# Altium schematic sheet FPGA-CFG.SchDoc(FPGA-CFG)
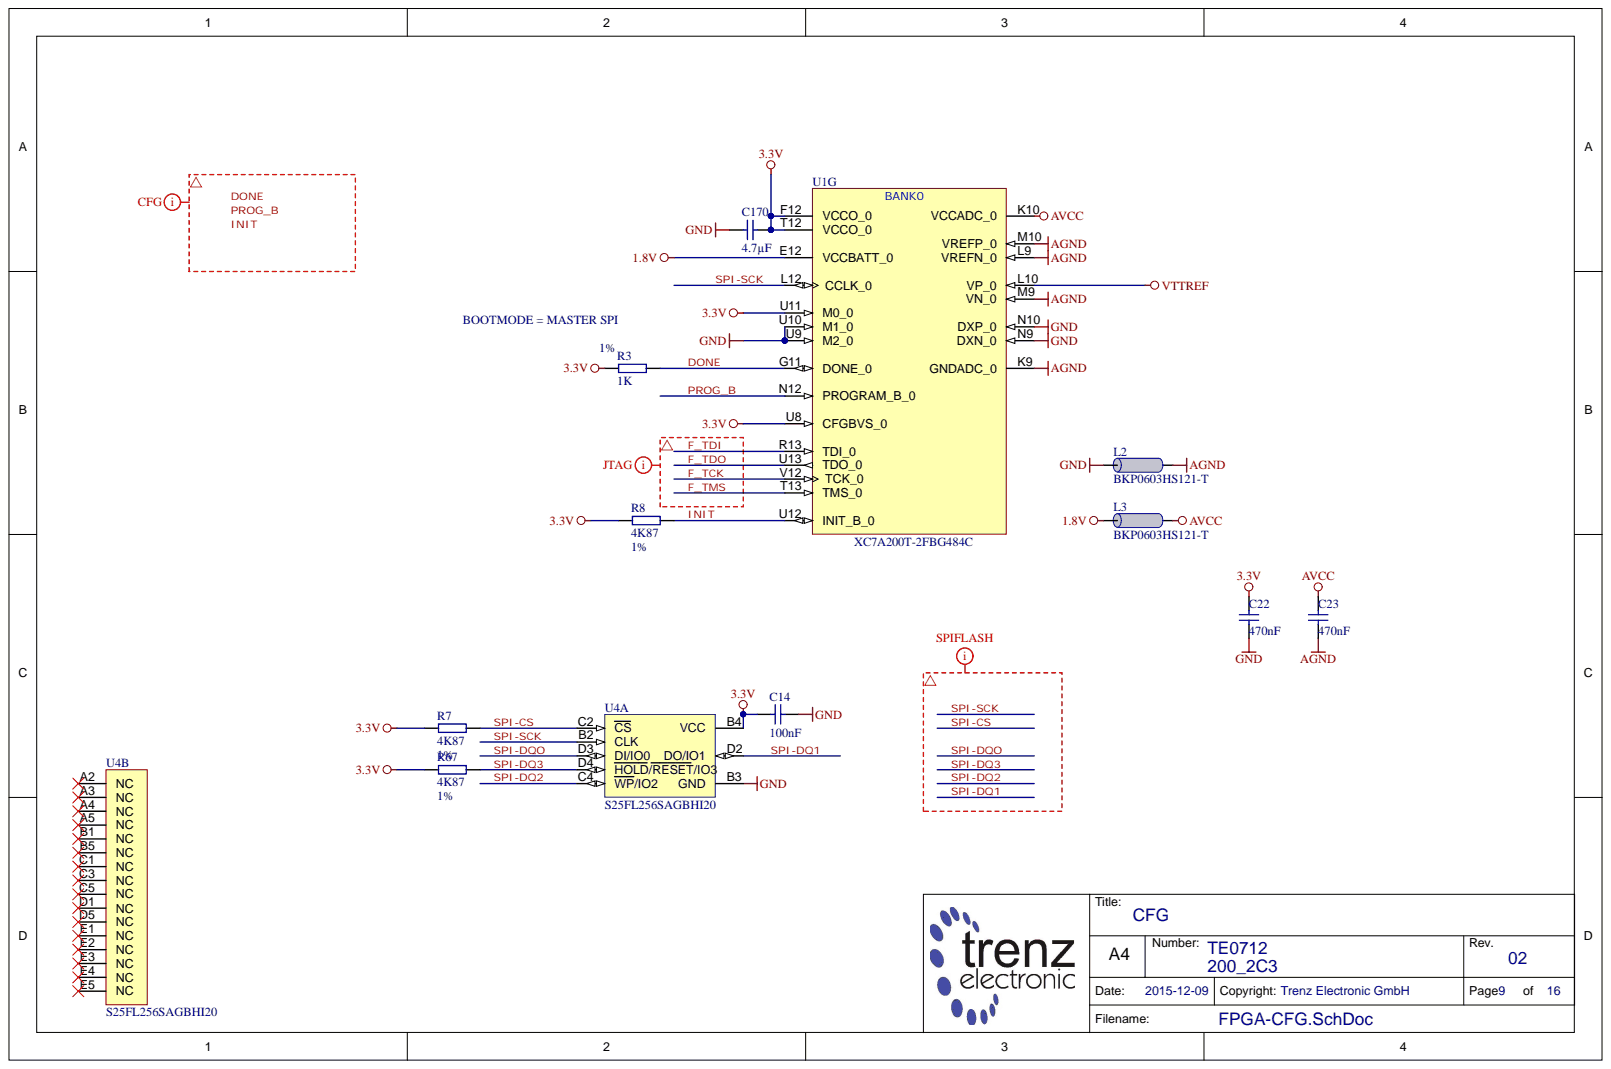
[diagram p0: FPGA-CFG.SchDoc]

## Parts
C14  100nF  [CAPC0603X33N]
C22  470nF  [CAPC0603X33N]
C23  470nF  [CAPC0603X33N]
C170  4.7µF  [CAPC1005X60N]
L2  BKP0603HS121-T  [INDC0603X33N]
L3  BKP0603HS121-T  [INDC0603X33N]
R3  1K  Tolerance: 1%  [RESC1005X40N]
R7  4K87  [RESC1005X40N]
R8  4K87  Tolerance: 1%  [RESC1005X40N]
R67  4K87  Tolerance: 1%  [RESC1005X40N]
U1  XC7A200T-2FBG484C  [BGA484C100P22X22_2300X2300X254N]  (part G)
U4  S25FL256SAGBHI20  [BGA24C100P5X5_800X600X120N]  (parts A,B)

## Nets
1.8V: L3.2 U1.E12(VCCBATT_0)
3.3V: C14.1 C22.1 C170.2 R3.1 R7.2 R8.2 R67.2 U1.F12(VCCO_0) U1.T12(VCCO_0) U1.U8(CFGBVS_0) U1.U11(M0_0) U4.B4(VCC)
AGND: C23.2 L2.1 U1.K9(GNDADC_0) U1.L9(VREFN_0) U1.M9(VN_0) U1.M10(VREFP_0)
AVCC: C23.1 L3.1 U1.K10(VCCADC_0)
GND: C14.2 C22.2 C170.1 L2.2 U1.N9(DXN_0) U1.N10(DXP_0) U1.U9(M2_0) U1.U10(M1_0) U4.B3(GND)
VTTREF: U1.L10(VP_0)
DONE: R3.2 U1.G11(DONE_0)
F_TCK: U1.V12(TCK_0)
F_TDI: U1.R13(TDI_0)
F_TDO: U1.U13(TDO_0)
F_TMS: U1.T13(TMS_0)
INIT: R8.1 U1.U12(INIT_B_0)
PROG_B: U1.N12(PROGRAM_B_0)
SPI-CS: R7.1 U4.C2(CS)
SPI-DQ1: U4.D2(DO/IO1)
SPI-DQ2: U4.C4(WP/IO2)
SPI-DQ3: R67.1 U4.D4(HOLD/RESET/IO3)
SPI-DQO: U4.D3(DI/IO0)
SPI-SCK: U1.L12(CCLK_0) U4.B2(CLK)
N00724:
N00725:
N00726:

Not connected: U4 A2(NC) A3(NC) A4(NC) A5(NC) B1(NC) B5(NC) C1(NC) C3(NC) C5(NC) D1(NC) D5(NC) E1(NC) E2(NC) E3(NC) E4(NC) E5(NC)

## Library data of the parts
C14 = 24915: KON 100nF 6.3V X5R SMD 10%; Database Table Name: C_0603_0201; Mixed: SMT; Preferred: Yes; Value: 100n; AN: 24915; Case: 0603 (0201); Component Kind: Standard; Library Name: TE.DbLib; Technology: X5R; Tolerance: 10%; Voltage: 6.3V
C22 C23 = 24921: KON 470nF X5R 6.3V SMD 20%; Database Table Name: C_0603_0201; Mixed: SMT; Preferred: Yes; Value: 470n; AN: 24921; Case: 0603 (0201); Component Kind: Standard; Library Name: TE.DbLib; Technology: X5R; Tolerance: 20%; Voltage: 6.3V
C170 = 24719: KON 4.7µF 6.3V X5R SMD 20%; Database Table Name: C_1005_0402; Mixed: SMT; Preferred: Yes; Value: 4.7u; Voltage: 6.3; AN: 24719; Case: 1005 (0402); Component Kind: Standard; Library Name: TE.DbLib; Technology: X5R; Tolerance: 20%
L2 L3 = 25393: Ferritdurchführung SMD 0201; Database Table Name: L_0603_0201; Mixed: SMT; Preferred: Yes; Tolerance: 25%; AN: 25393; Case: 0603 (0201); Component Kind: Standard; H-ART: BKP0603HS121-T; Library Name: TE.DbLib; Trenz-Stock: Yes; HelpURL: B:\AD\adlib\datasheet\MULTILAYER CHIP BEAD INDUCTORS BK
R3 = 22752: WID SMD 1K 1% 0,063W; Database Table Name: R_1005_0402; H-ART: RC1005F102CS; Mixed: SMT; Preferred: Yes; AN: 22752; Case: 1005 (0402); Component Kind: Standard; Library Name: TE.DbLib; Value: 1K
R7 R8 R67 = 25921: WID SMD 4K87 1% 0,063W; Database Table Name: R_1005_0402; Mixed: SMT; Preferred: Yes; Value: 4.87k; AN: 25921; Case: 1005 (0402); Component Kind: Standard; Library Name: TE.DbLib
  R7: Tolerance: 1%
U1 = 25321: Database Table Name: PROG_LOGIC; Mixed: SMT; Trenz-Stock: Yes; AN: 25321; Case: BGA484; Component Kind: Standard; Description: XC7A200T-2FBG484C; H-ART: XC7A200T-2FBG484C; Library Name: TE.DbLib; HelpURL: B:\AD\adlib\datasheet\XC7
U4 = 25945: Database Table Name: FLASH; Mixed: SMT; Preferred: Yes; AN: 25945; Case: TFBGA24; Component Kind: Standard; Description: S25FL256SAGBHI20; Library Name: TE.DbLib; Trenz-Stock: Yes; HelpURL: B:\AD\adlib\datasheet\S25FL256SAGNFI00
